# Altium schematic sheet AN-DGX - Arduino Connectors.SchDoc("AN-DGX - Arduino Connectors")
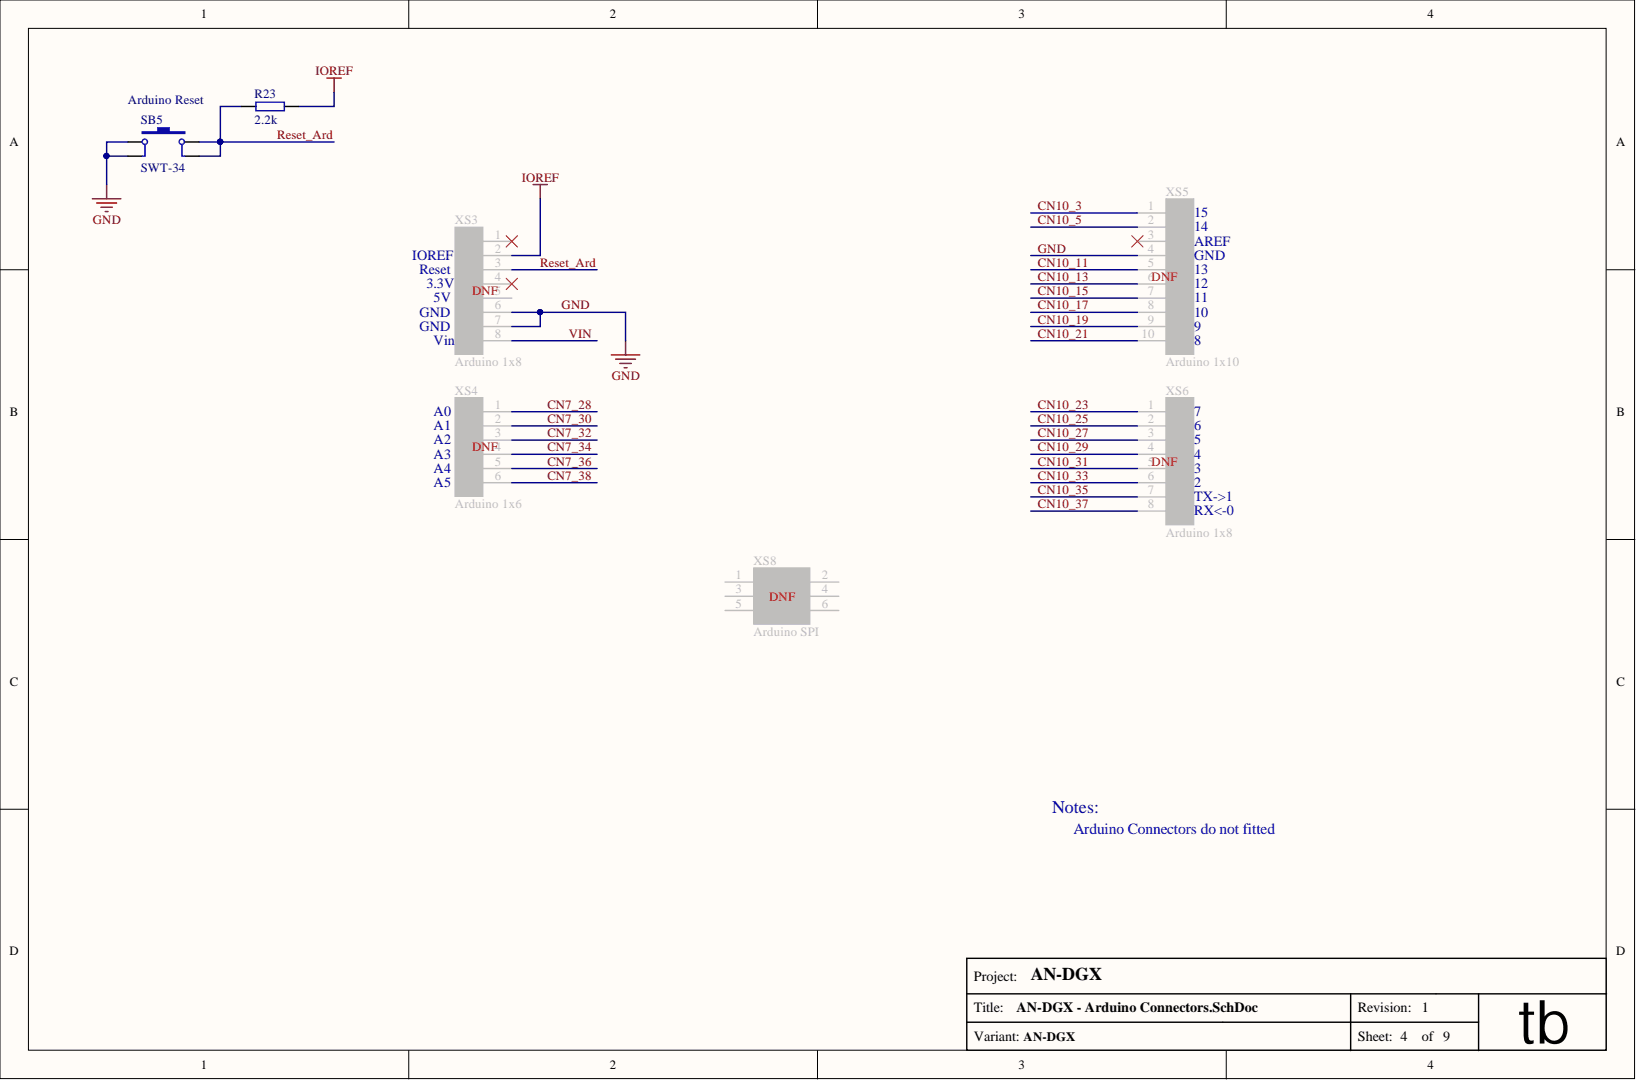
[diagram p0: AN-DGX - Arduino Connectors.SchDoc]

## Parts
R23  value 2.2k  [0603_RESISTOR]  (hidden comment: Resistor)
SB5  Arduino Reset  value SWT-34  [Key 6.2x6.2]
XS3  value Arduino 1x8  [Conn_Arduino_8p_2.54_End]  (hidden comment: conn_Arduino_8p)
XS4  value Arduino 1x6  [Conn_Arduino_6p_2.54_End]  (hidden comment: conn_Arduino_6p)
XS5  value Arduino 1x10  [Conn_Arduino_10p_2.54_End]  (hidden comment: conn_Arduino_10p)
XS6  value Arduino 1x8  [Conn_Arduino_8p_2.54_End]  (hidden comment: conn_Arduino_8p)
XS8  Arduino SPI  [Conn_2x3p_2.54]

## Nets
IOREF: R23.1 XS3.2
CN7_28: XS4.1
CN7_30: XS4.2
CN7_32: XS4.3
CN7_34: XS4.4
CN7_36: XS4.5
CN7_38: XS4.6
CN10_3: XS5.1
CN10_5: XS5.2
CN10_11: XS5.5
CN10_13: XS5.6
CN10_15: XS5.7
CN10_17: XS5.8
CN10_19: XS5.9
CN10_21: XS5.10
CN10_23: XS6.1
CN10_25: XS6.2
CN10_27: XS6.3
CN10_29: XS6.4
CN10_31: XS6.5
CN10_33: XS6.6
CN10_35: XS6.7
CN10_37: XS6.8
GND: SB5.1 SB5.3 XS3.6 XS3.7 XS5.4
Reset_Ard: R23.2 SB5.2 SB5.4 XS3.3
VIN: XS3.8

Not connected: XS3 1 4 5; XS5 3; XS8 1 2 3 4(DNF) 5 6

## Library data of the parts
R23 = Resistor: Manufacturer Part: CRCW06032K20JNEA; Manufacturer: Vishay / Dale; Type of Component: Resistor; Supplier 3 Part: 023266; Supplier 3 Link: http://voron.ua/catalog/023266; Supplier 3: http://voron.ua/; Supplier 2 Part: 147328; Supplier 2 Link: http://imrad.com.ua/ru/res-0603-2k2-5-product-70186; Supplier 2: http://imrad.com.ua/; Supplier 1 Part: 0603 2.2k; Supplier 1 Link: aliexpress.com/store/product/0603-SMD-Resistor-5-2-2K-ohm-5000pcs-lot/506373_32308080995.html?spm=2114.12010108.1000023.109.DtVsO1; Supplier 1: aliexpress.com; Package / Case: 0603; Manufacturer Link: http://www.vishay.com/product?docid=20035; Component Kind: Standard; Library Name: RPI-VITA.IntLib
SB5 = SB_Tactile: Tactile Switches; Type of Component: Tact Switch; Supplier 3 Part: 017636; Supplier 3 Link: http://voron.ua/catalog/017636; Supplier 3: http://voron.ua/; Supplier 2 Part: 41517; Supplier 2 Link: http://imrad.com.ua/ru/swt-34-h5mm; Supplier 2: http://imrad.com.ua/; Supplier 1 Link: aliexpress.com/item/50-Pcs-Momentary-Tact-Tactile-Switch-SMD-SMT-PCB-4-Pin-6-x-6-x/32606731512.html?spm=2114.03010208.3.152.FgYqgH&ws_ab_test=searchweb0_0,searchweb201602_1_10065_10068_10501_10503_10000032_119_10000025_10000029_430_10000028_10060_10062_10056_10055_10000062_10054_10059_10099_10000022_10000012_10103_10000015_10102_10096_10000018_10000019_10000056_10000059_10052_10053_10107_10050_10106_10051_10000053_10000007_10000050_10117_10084_10083_10118_10000047_10080_10082_10081_10110_10111_10112_10113_10114_10115_10000041_10000044_10078_10079_10000038_429_10073_10000035_10121-10503_10501,searchweb201603_1,afswitch_1,single_sort_1_default&btsid=da13b4f2-05a9-443d-b1b0-b3b976e778d9; Supplier 1: aliexpress.com; Package / Case: 6.2 x 6.2 x 5; Component Kind: Standard; Library Name: RPI-VITA.IntLib
XS3 XS6 = conn_Arduino_8p: PLS-8 2.54mm Pitch, 10.5mm High; Manufacturer: China; Type of Component: Arduino Header 1x8; Supplier Link: http://aliexpress.com/item/40PCS-x-8-Pin-40x-6-Pin-Stackable-Female-Shield-Header-Tall-Stacking-Kit-Special-long/689203895.html; Supplier: aliexpress.com; Package / Case: Header 8; Component Kind: Standard; Library Name: RPI-VITA.IntLib
XS4 = conn_Arduino_6p: PLS-6 2.54mm Pitch, 10.5mm High; Component Kind: Standard; Library Name: RPI-VITA.IntLib
XS5 = conn_Arduino_10p: PLS-10 2.54mm Pitch, 10.5mm High; Manufacturer: China; Type of Component: Arduino Header 1x10; Supplier Link: http://aliexpress.com/item/20PCS-2x18-Pin-2-54-mm-Stackable-Long-Legs-Femal-Header-For-Arduino-Shield/1524702262.html; Supplier: aliexpress.com; Package / Case: Header 10; Component Kind: Standard; Library Name: RPI-VITA.IntLib
XS8 = conn_stackable_2x3p: Stackable 2x3 2.54mm Pitch; Value: Arduino SPI; Component Kind: Standard; Library Name: RPI-VITA.IntLib
